# Altium schematic sheet Serial.SchDoc(Serial)
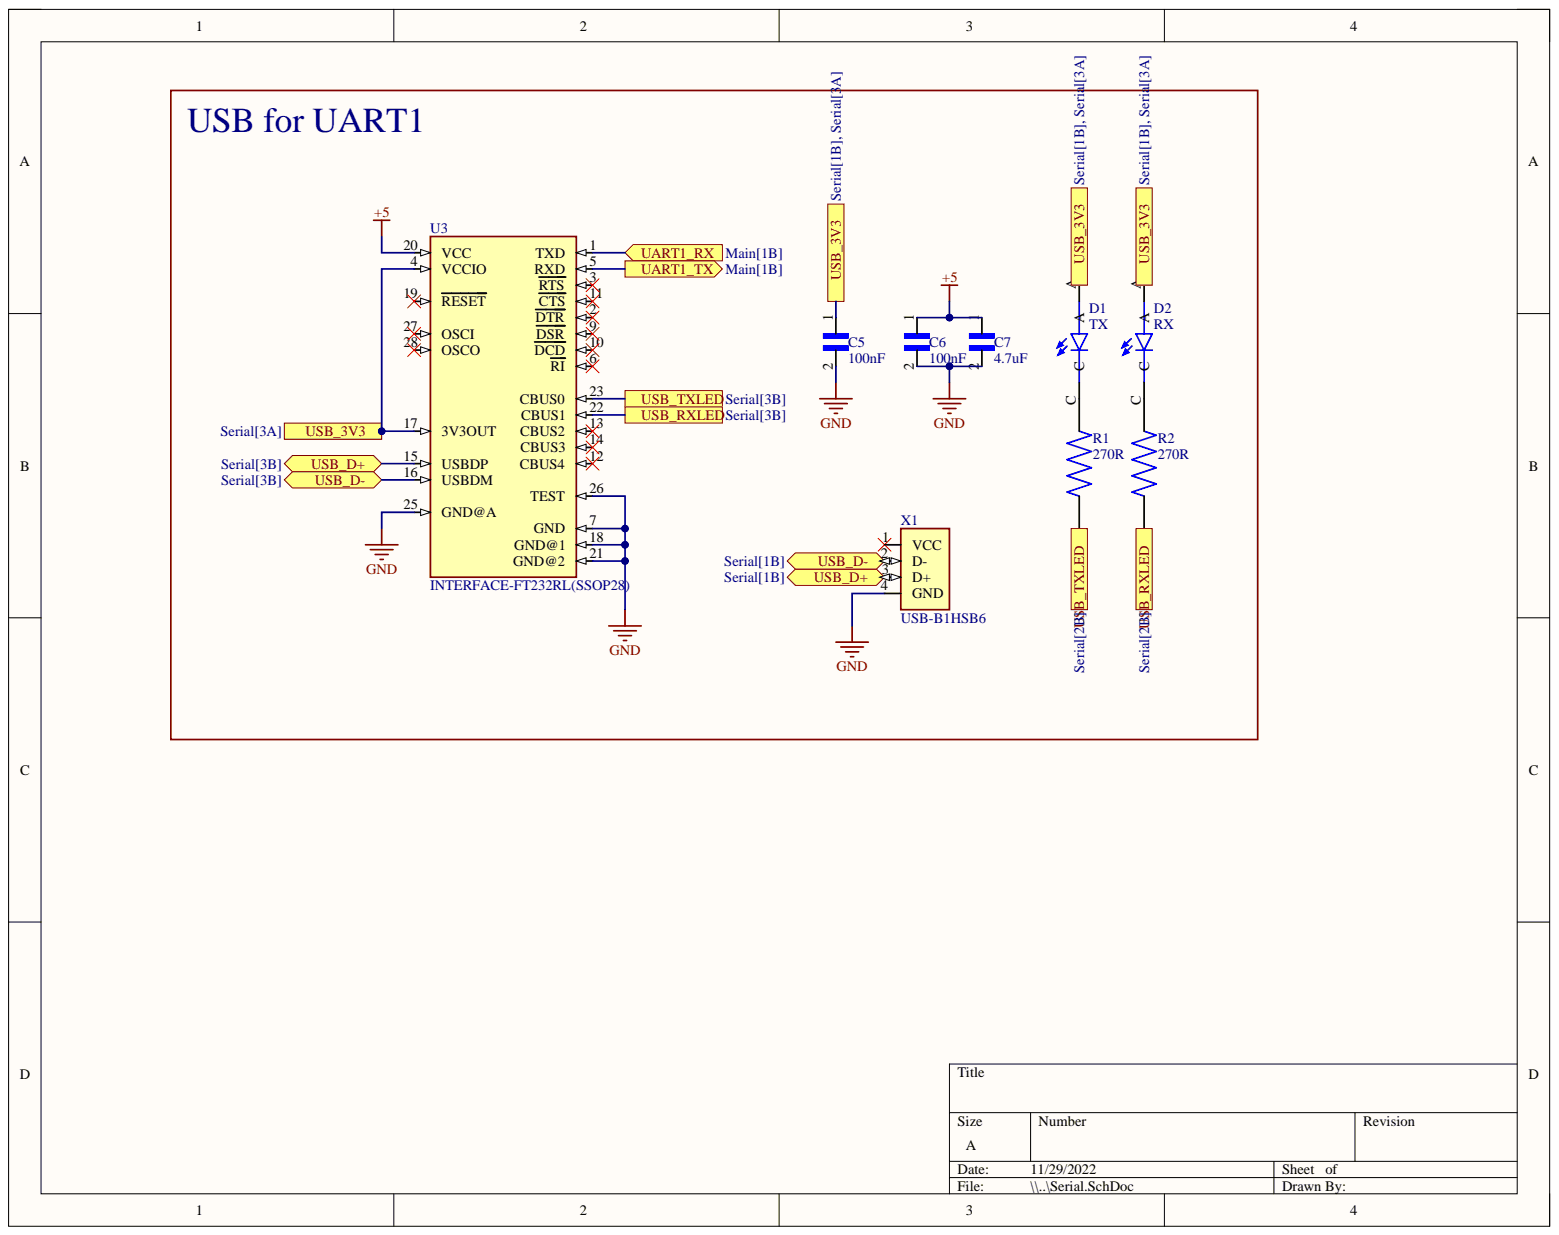
[diagram p0: Serial.SchDoc]

## Parts
C5  100nF  [CAPC2012X140N]
C6  100nF  [CAPC2012X140N]
C7  4.7uF  [CAPC2012X140N]
D1  TX  [LEDC3216X110]
D2  RX  [LEDC3216X110]
R1  270R  [RESC2012X55N]
R2  270R  [RESC2012X55N]
U3  INTERFACE-FT232RL(SSOP28)  [SSOP28-0.65-10.2X5.2MM]
X1  USB-B1HSB6  [OST_USB-B1HSB6]

## Ports
UART1_RX, UART1_TX, USB_3V3, USB_D+, USB_D-, USB_RXLED, USB_TXLED

## Nets
+5: C6.1 C7.1 U3.20(VCC)
GND: C5.2 C6.2 C7.2 U3.7(GND) U3.18(GND@1) U3.21(GND@2) U3.25(GND@A) U3.26(TEST) X1.4(GND)
UART1_RX: U3.1(TXD) ; port UART1_RX
UART1_TX: U3.5(RXD) ; port UART1_TX
USB_3V3: C5.1 D1.A D2.A U3.4(VCCIO) U3.17(3V3OUT) ; port USB_3V3
USB_RXLED: R2.1 U3.22(CBUS1) ; port USB_RXLED
USB_TXLED: R1.1 U3.23(CBUS0) ; port USB_TXLED
NetD1_C: D1.C R1.2
NetD2_C: D2.C R2.2
NetU3_15: U3.15(USBDP) X1.3(D+)
NetU3_16: U3.16(USBDM) X1.2(D-)

Not connected: U3 2(DTR) 3(RTS) 6(RI) 9(DSR) 10(DCD) 11(CTS) 12(CBUS4) 13(CBUS2) 14(CBUS3) 19(RESET) 27(OSCI) 28(OSCO); X1 1(VCC)

## Library data of the parts
C5 C6 = 08055G104ZAT1A: CAPACITOR, 0805, 100NF, 50V; Capacitor Application:General Purpose; Dielectric Characteristic:Y5V; Capacitance:0.1ÂµF; Capacitance Tolerance:-20% to +80%; Voltage Rating:50V; Capacitor Case Style:0805; Capacitor Mounting:SMD; Operating Temperature Range:-25Â°C to +85Â°C; SVHC:No SVHC (15-Dec-2010); External Depth:0.95mm; External Length / Height:2mm; External Width:1.25mm; Insulation Resistance:10000Mohm; No. of Pins:2; Operating Temperature Max:85Â°C; Operating Temperature Min:-25Â°C; Package / Case:0805; Reel Quantity:3000; Tape Width:8mm; Temperature Coefficient:+22%, -82%; Terminal Type:Surface Mount (SMD, SMT); Termination Type:SMD; Tolerance +:80%; Tolerance -:20%; Voltage Rating VDC:50V; MF: {'manufacturer': u'AVX'}; Availability: Unavailable; Component Kind: Standard; Library Name: 08055G104ZAT1A.SchLib; MP: 08055G104ZAT1A; Package: None; Price: None
C7 = CL21A475KOCLRNC: 0805 X5R 4.7UF 10% 16V; MF: {'manufacturer': u'Samsung'}; Availability: Unavailable; Component Kind: Standard; MP: CL21A475KOCLRNC; Package: None; Price: None
D1 D2 = LTST-C230KRKT: LED SMT RED CLR 1206 TAPE; MF: {'manufacturer': u'Lite-On Electronics'}; Availability: Unavailable; Component Kind: Standard; MP: LTST-C230KRKT; Package: None; Price: None
R1 R2 = TNPW0805270RBETA: MF: {'manufacturer': u'Vishay'}; Availability: Unavailable; Component Kind: Standard; MP: TNPW0805270RBETA; Package: None; Price: None
U3 = INTERFACE-FT232RL(SSOP28): 310070022; MF: {'manufacturer': u'FTDI, Future'}; Availability: Unavailable; Component Kind: Standard; MP: FT232RL; Package: None; Price: None
X1 = USB-B1HSB6: Conn Usb Type b r/a Black; MF: {'manufacturer': u'On Shore'}; Availability: Unavailable; Component Kind: Standard; MP: USB-B1HSB6; Package: None; Price: None
